# Altium schematic sheet EXT_Interface.SchDoc
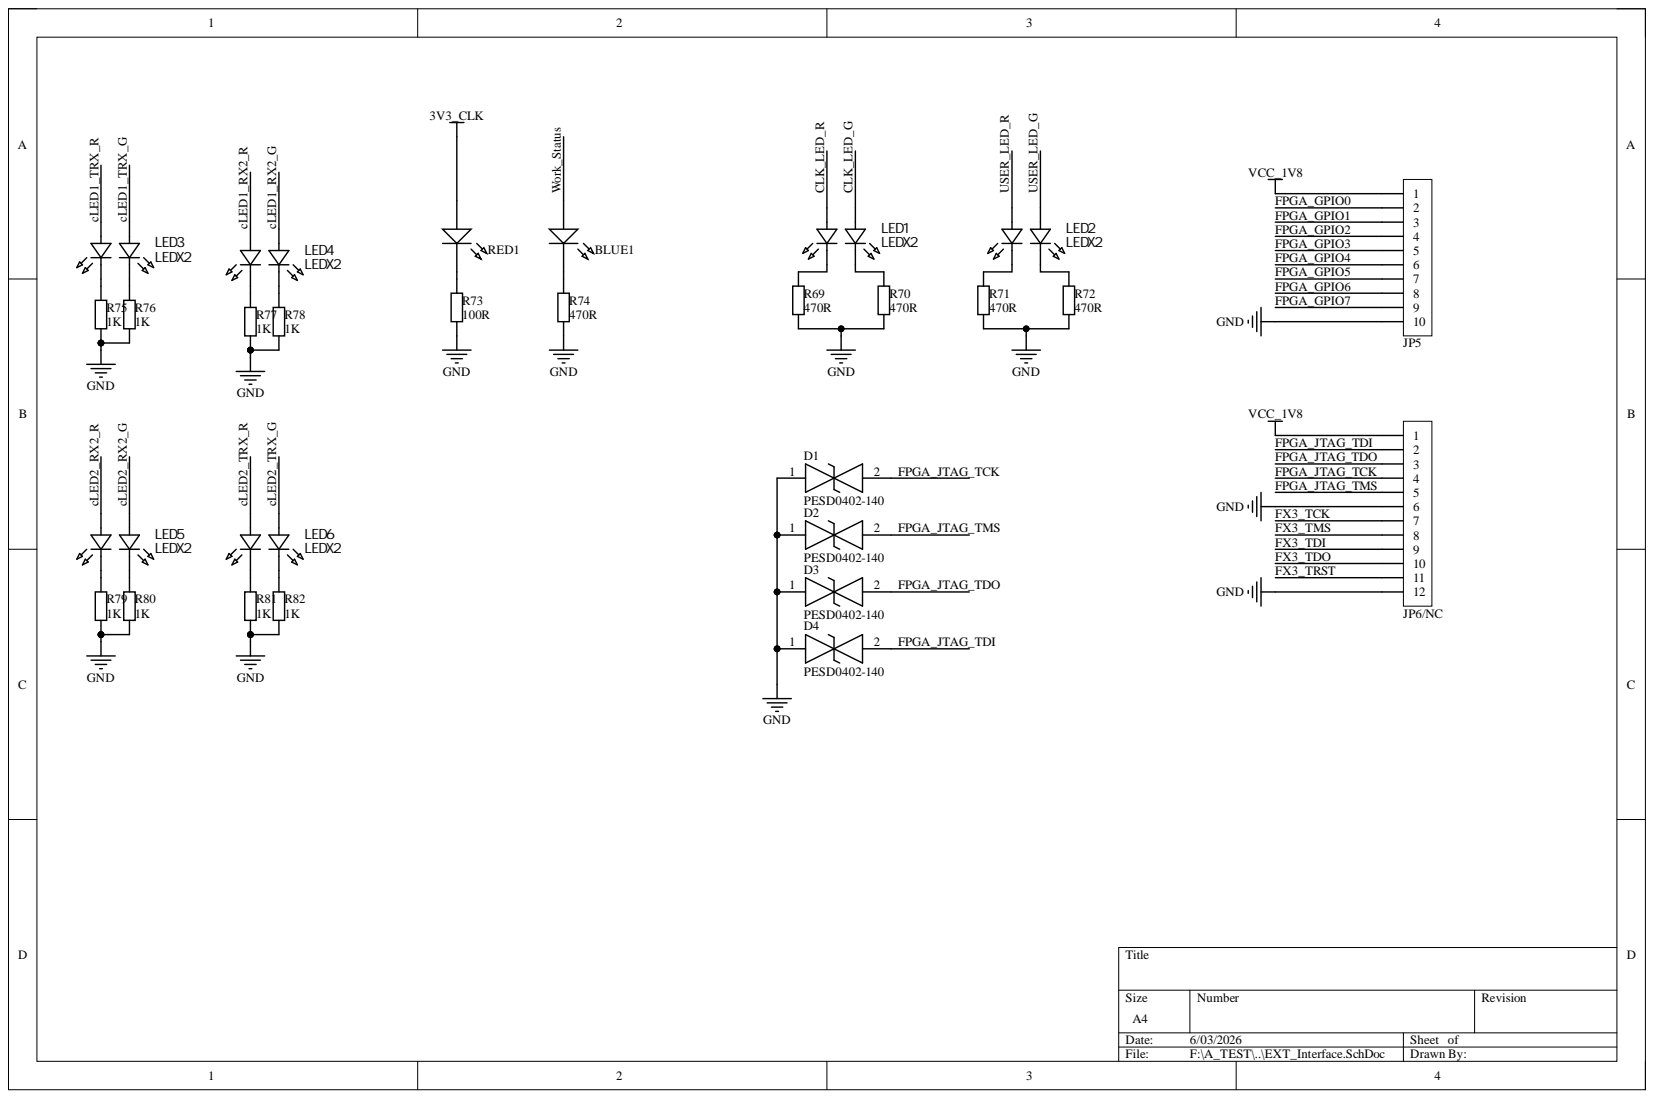
[diagram p0: EXT_Interface.SchDoc]

## Parts
BLUE1  LED  [LED 0402 B]  (value not drawn)
D1  PESD0402-140  [DIONC1005X43N]
D2  PESD0402-140  [DIONC1005X43N]
D3  PESD0402-140  [DIONC1005X43N]
D4  PESD0402-140  [DIONC1005X43N]
JP5  HDR-1X10  [MX 1.25-WS-10P]  (value not drawn)
JP6/NC  HDR-1X12  [HDR2.54-LI-2x6P]  (value not drawn)
LED1  LEDX2  [LED-SMD_4P-L1.6-W1.5_F.1615.00003-P4-1615R1G1TA2-06T-001]
LED2  LEDX2  [LED-SMD_4P-L1.6-W1.5_F.1615.00003-P4-1615R1G1TA2-06T-001]
LED3  LEDX2  [LED-SMD_4P-L1.6-W1.5_F.1615.00003-P4-1615R1G1TA2-06T-001]
LED4  LEDX2  [LED-SMD_4P-L1.6-W1.5_F.1615.00003-P4-1615R1G1TA2-06T-001]
LED5  LEDX2  [LED-SMD_4P-L1.6-W1.5_F.1615.00003-P4-1615R1G1TA2-06T-001]
LED6  LEDX2  [LED-SMD_4P-L1.6-W1.5_F.1615.00003-P4-1615R1G1TA2-06T-001]
R69  470R  [R 0402_L]
R70  470R  [R 0402_L]
R71  470R  [R 0402_L]
R72  470R  [R 0402_L]
R73  100R  [R 0402_L]
R74  470R  [R 0402_L]
R75  1K  [R 0402_L]
R76  1K  [R 0402_L]
R77  1K  [R 0402_L]
R78  1K  [R 0402_L]
R79  1K  [R 0402_L]
R80  1K  [R 0402_L]
R81  1K  [R 0402_L]
R82  1K  [R 0402_L]
RED1  LED  [LED 0402 R]  (value not drawn)

## Nets
3V3_CLK: RED1.1
GND: D1.1 D2.1 D3.1 D4.1 JP5.10 JP6/NC.6 JP6/NC.12 R69.1 R70.1 R71.1 R72.1 R73.1 R74.1 R75.1 R76.1 R77.1 R78.1 R79.1 R80.1 R81.1 R82.1
VCC_1V8: JP5.1 JP6/NC.1
cLED1_RX2_G: LED4.4
cLED1_RX2_R: LED4.3
cLED1_TRX_G: LED3.4
cLED1_TRX_R: LED3.3
cLED2_RX2_G: LED5.4
cLED2_RX2_R: LED5.3
cLED2_TRX_G: LED6.4
cLED2_TRX_R: LED6.3
CLK_LED_G: LED1.4
CLK_LED_R: LED1.3
FPGA_GPIO0: JP5.2
FPGA_GPIO1: JP5.3
FPGA_GPIO2: JP5.4
FPGA_GPIO3: JP5.5
FPGA_GPIO4: JP5.6
FPGA_GPIO5: JP5.7
FPGA_GPIO6: JP5.8
FPGA_GPIO7: JP5.9
FPGA_JTAG_TCK: D1.2 JP6/NC.4
FPGA_JTAG_TDI: D4.2 JP6/NC.2
FPGA_JTAG_TDO: D3.2 JP6/NC.3
FPGA_JTAG_TMS: D2.2 JP6/NC.5
FX3_TCK: JP6/NC.7
FX3_TDI: JP6/NC.9
FX3_TDO: JP6/NC.10
FX3_TMS: JP6/NC.8
FX3_TRST: JP6/NC.11
USER_LED_G: LED2.4
USER_LED_R: LED2.3
Work_Status: BLUE1.1
NetBLUE1_2: BLUE1.2 R74.2
NetLED1_1: LED1.1 R70.2
NetLED1_2: LED1.2 R69.2
NetLED2_1: LED2.1 R72.2
NetLED2_2: LED2.2 R71.2
NetLED3_1: LED3.1 R76.2
NetLED3_2: LED3.2 R75.2
NetLED4_1: LED4.1 R78.2
NetLED4_2: LED4.2 R77.2
NetLED5_1: LED5.1 R80.2
NetLED5_2: LED5.2 R79.2
NetLED6_1: LED6.1 R82.2
NetLED6_2: LED6.2 R81.2
NetR73_2: R73.2 RED1.2

## Library data of the parts
BLUE1 RED1 = LED: 通用; Component Kind: Standard; Library Name: A_Schlib.SchLib
D1-D4 = PESD0402-140: TVS Diode (Bi-directional); Manufacturer_Name: LITTELFUSE; Arrow Part Number: PESD0402-140; Arrow Price/Stock: https://www.arrow.com/en/products/pesd0402-140/littelfuse?utm_currency=USD&region=nac; Component Kind: Standard; Datasheet Link: https://www.littelfuse.com/~/media/electronics/product_specifications/polymer_esd_suppressors/littelfuse_polymer_esd_suppressor_pesd0402_140_product_specification.pdf.pdf; Height: 0.43mm; Library Name: SamacSys.SchLib; Manufacturer_Part_Number: PESD0402-140; Mouser Part Number: 650-PESD0402-140; Mouser Price/Stock: https://www.mouser.co.uk/ProductDetail/Littelfuse/PESD0402-140?qs=y1EbU1W0OrtTHVfbQV6MTg%3D%3D
JP5 = Header 10: 10P接插件; 版权归属: 淘宝ID:黄晶宝石; BOM名称: 10P接插件; Component Kind: Standard; 学习资源: https://shop463601444.taobao.com; Library Name: 2.54间距排针排母插件贴片.SchLib
JP6/NC = Header 12: 12P接插件; 版权归属: 封装库来自淘宝卖家 ID：黄晶宝石; BOM名称: 12P接插件; Component Kind: Standard; 学习资源: https://shop463601444.taobao.com; Library Name: 2.54间距排针排母插件贴片.SchLib
LED1-LED6 = LEDX2: Manufacturer: Amicc(欧密格光电); Add into BOM: yes; Device: A-SP1942R6GHC-A01-2T; Illumination Color: 红色,翠绿色; Lens Color: 无色透明透镜; Luminous Intensity: 28.5mcd~72mcd；180mcd~450mcd; Mounting Sytle: 正贴; Power(Watts): 95mW；60mW; Viewing Angle: 130°; Wavelength - Dominant: 520nm~535nm；616nm~626nm; Component Kind: Standard; Convert to PCB: yes; Datasheet: https://atta.szlcsc.com/upload/public/pdf/source/20231108/6CC0465F260EB0273BA2FCC598291934.pdf; JLCPCB Part Class: 扩展库; LCSC Part Name: 1615红绿双色正贴; Library Name: A_Schlib.SchLib; Manufacturer Part: A-SP1942R6GHC-A01-2T; Operating Temperature: -40℃~+80℃; PCB3D: LED-SMD_4P-L1.6-W1.5_F.1615.00003-P4-1615R1G1TA2-06T-001; Supplier: LCSC; Supplier Footprint: SMD-4P,1.6x1.5mm; Supplier Part: C19171224; Symbol: A-SP1942R6GHC-A01-2T
R69-R82 = Res: 通用; Component Kind: Standard; Library Name: A_Schlib.SchLib
  R69-R72 R74: value: 470R
  R73: value: 100R
  R75-R82: value: 1K
